# Altium schematic sheet UAARG Autopilot.SchDoc
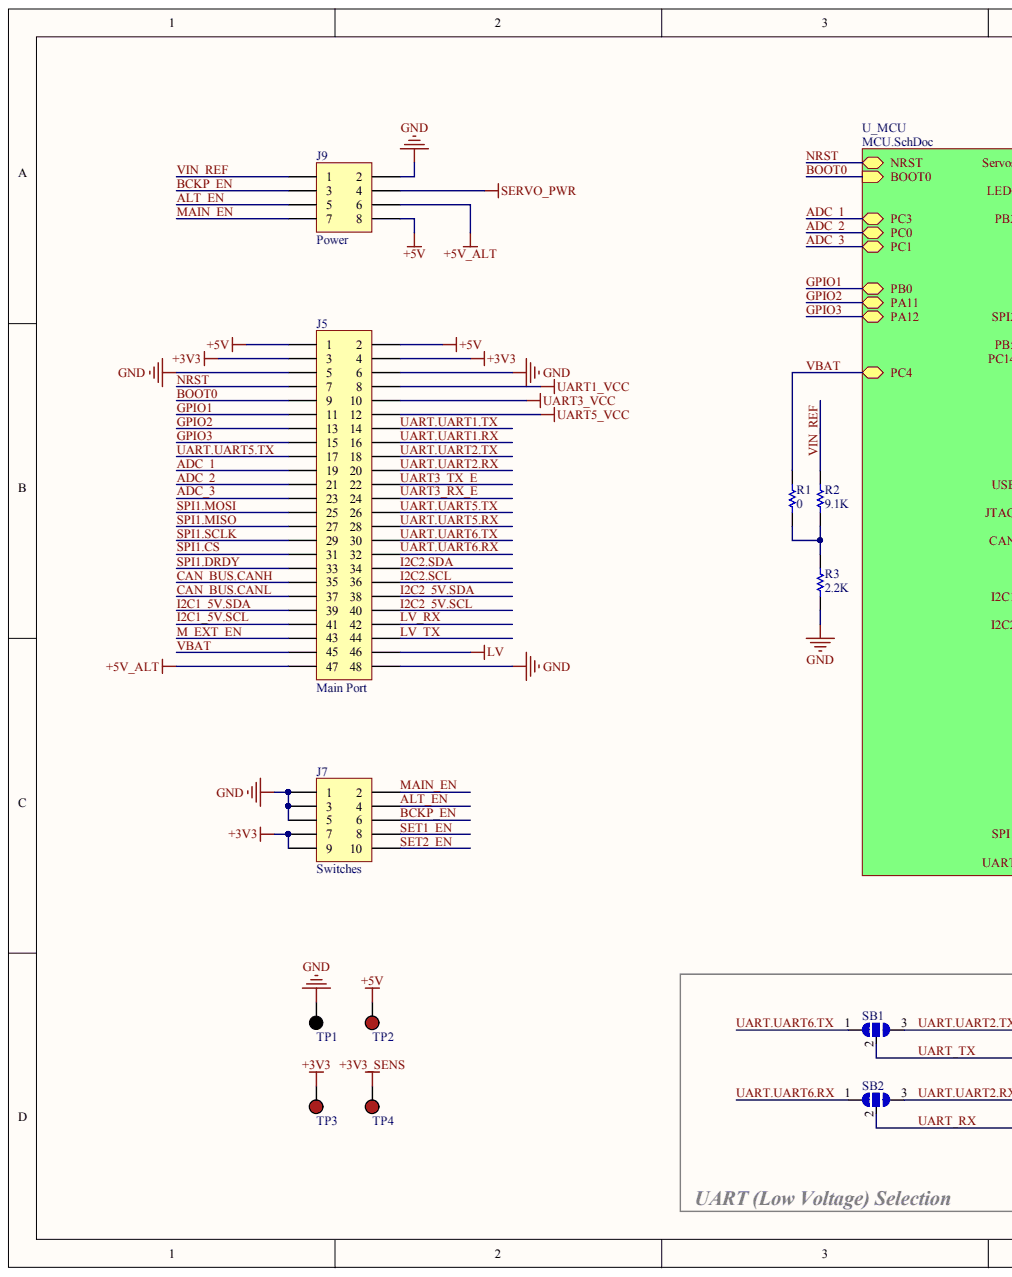
[diagram p0: UAARG Autopilot.SchDoc, left]
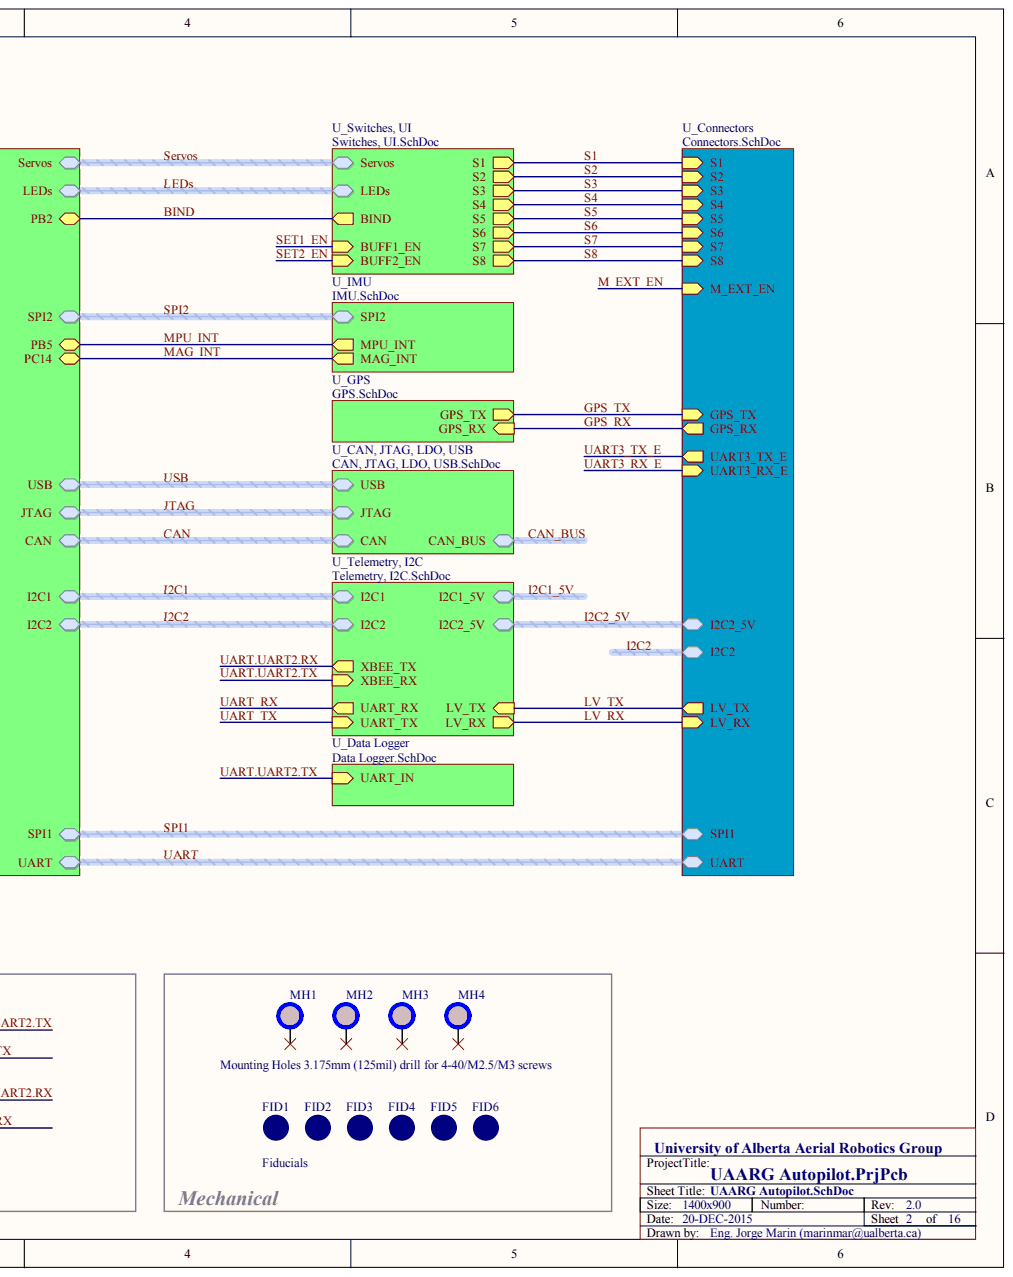
[diagram p1: UAARG Autopilot.SchDoc, right]

## Parts
FID1  Ficudial  [FIDUCIAL_1]  (value not drawn)  (no BOM)
FID2  Ficudial  [FIDUCIAL_1]  (value not drawn)  (no BOM)
FID3  Ficudial  [FIDUCIAL_1]  (value not drawn)  (no BOM)
FID4  Ficudial  [FIDUCIAL_1]  (value not drawn)  (no BOM)
FID5  Ficudial  [FIDUCIAL_1]  (value not drawn)  (no BOM)
FID6  Ficudial  [FIDUCIAL_1]  (value not drawn)  (no BOM)
J5  Main Port  [HDR_2X24]  (no BOM)
J7  Switches  [HDR_2X05]  (no BOM)
J9  Power  [2X04]  (no BOM)
MH1  Mounting Hole  [MOUNTINGHOLE_6]  (no BOM)
MH2  Mounting Hole  [MOUNTINGHOLE_6]  (no BOM)
MH3  Mounting Hole  [MOUNTINGHOLE_6]  (no BOM)
MH4  Mounting Hole  [MOUNTINGHOLE_6]  (no BOM)
R1  value 0  [RES_0402[1005M]]  (hidden comment: RES)
R2  value 9.1K  [RES_0603[1608M]]  (hidden comment: RES)
R3  value 2.2K  [RES_0603[1608M]]  (hidden comment: RES)
SB1  SMD Jumper 1  [SMD JUMPER 1]  (value not drawn)  (no BOM)
SB2  SMD Jumper 1  [SMD JUMPER 1]  (value not drawn)  (no BOM)
TP1  Test Point GND  [TP_TH_1_SILK]  (value not drawn)  (no BOM)
TP2  Test Point VCC  [TP_TH_1_SILK]  (value not drawn)  (no BOM)
TP3  Test Point VCC  [TP_TH_1_SILK]  (value not drawn)  (no BOM)
TP4  Test Point VCC  [TP_TH_1_SILK]  (value not drawn)  (no BOM)

## Nets
+3V3: J5.3 J5.4 J7.7 J7.9 TP3.1
+3V3_SENS: TP4.1
+5V: J5.1 J5.2 J9.8 TP2.1
+5V_ALT: J5.47 J9.6
GND: J5.5 J5.6 J5.48 J7.1 J7.3 J7.5 J9.2 R3.1 TP1.1
LV: J5.46
SERVO_PWR: J9.4
UART1_VCC: J5.8
UART3_VCC: J5.10
UART5_VCC: J5.12
ADC_1: J5.19
ADC_2: J5.21
ADC_3: J5.23
ALT_EN: J7.4 J9.5
BCKP_EN: J7.6 J9.3
BOOT0: J5.9
CAN_BUS.CANH: J5.35
CAN_BUS.CANL: J5.37
GPIO1: J5.11
GPIO2: J5.13
GPIO3: J5.15
I2C1_5V.SCL: J5.41
I2C1_5V.SDA: J5.39
I2C2.SCL: J5.36
I2C2.SDA: J5.34
I2C2_5V.SCL: J5.40
I2C2_5V.SDA: J5.38
LV_RX: J5.42
LV_TX: J5.44
M_EXT_EN: J5.43
MAIN_EN: J7.2 J9.7
NRST: J5.7
SET1_EN: J7.8
SET2_EN: J7.10
SPI1.CS: J5.31
SPI1.DRDY: J5.33
SPI1.MISO: J5.27
SPI1.MOSI: J5.25
SPI1.SCLK: J5.29
UART3_RX_E: J5.24
UART3_TX_E: J5.22
UART.UART1.RX: J5.16
UART.UART1.TX: J5.14
UART.UART2.RX: J5.20 SB2.3
UART.UART2.TX: J5.18 SB1.3
UART.UART5.RX: J5.28
UART.UART5.TX: J5.17 J5.26
UART.UART6.RX: J5.32 SB2.1
UART.UART6.TX: J5.30 SB1.1
UART_RX: SB2.2
UART_TX: SB1.2
VBAT: J5.45 R1.2
VIN_REF: J9.1 R2.2
NetMH1_1: MH1.1
NetMH2_1: MH2.1
NetMH3_1: MH3.1
NetMH4_1: MH4.1
NetR1_1: R1.1 R2.1 R3.2
BIND:
CAN.RX:
CAN.TX:
GPS_RX:
GPS_TX:
I2C1.SCL:
I2C1.SDA:
JTAG.RST:
JTAG.TCK:
JTAG.TDI:
JTAG.TDO:
JTAG.TMS:
LEDS.LED1:
LEDS.LED2:
LEDS.LED3:
LEDS.LED4:
LEDS.LED5:
MAG_INT:
MPU_INT:
S1:
S2:
S3:
S4:
S5:
S6:
S7:
S8:
SERVOS.SERVO1:
SERVOS.SERVO2:
SERVOS.SERVO3:
SERVOS.SERVO4:
SERVOS.SERVO5:
SERVOS.SERVO6:
SERVOS.SERVO7:
SERVOS.SERVO8:
SPI2.CS_BARO:
SPI2.CS_MPU:
SPI2.MISO:
SPI2.MOSI:
SPI2.SCLK:
UART.UART3.RX:
UART.UART3.TX:
USB.D_N:
USB.D_P:
USB.VBUS:

## Library data of the parts
FID1-FID6 = Ficudial: Fiducial; Library Name: UAARG Autopilot.SchLib
J5 = Header 24X2: Header, 24-Pin, Dual row; Library Name: Miscellaneous Connectors.IntLib; Signal Integrity: Connector
J7 = Header 5X2: Header, 5-Pin, Dual row; Library Name: Miscellaneous Connectors.IntLib; Signal Integrity: Connector
J9 = Header 4X2: Header, 4-Pin, Dual row; Library Name: Miscellaneous Connectors.IntLib; Signal Integrity: Connector
R1 = RES: Resistor; Manufacturer: Yageo; Manufacturer Part Number: RC0402JR-070RL; Component Kind: Standard; ComponentLink1Description: Datasheet; ComponentLink1URL: http://www.yageo.com/NewPortal/yageodocoutput?fileName=/pdf/R-Chip/PYu-RC_Group_51_RoHS_L_3.pdf; Signal Integrity: Res Semi; Simulation: RES; Supplier 1: Digi-Key; Supplier Part Number 1: 311-0.0JRCT-ND; Library Name: UAARG Autopilot.SchLib
R2 = RES: Resistor; Manufacturer: Yageo; Manufacturer Part Number: RC0603FR-079K1L; Component Kind: Standard; ComponentLink1Description: Datasheet; ComponentLink1URL: http://www.yageo.com/NewPortal/yageodocoutput?fileName=/pdf/R-Chip/PYu-RC_Group_51_RoHS_L_3.pdf; Signal Integrity: Res Semi; Simulation: RES; Supplier 1: Digi-Key; Supplier Part Number 1: 311-9.10KHRCT-ND; Library Name: UAARG Autopilot.SchLib
R3 = RES: Resistor; Manufacturer: Yageo; Manufacturer Part Number: RC0603FR-072K2L; Component Kind: Standard; ComponentLink1Description: Datasheet; ComponentLink1URL: http://www.yageo.com/NewPortal/yageodocoutput?fileName=/pdf/R-Chip/PYu-RC_Group_51_RoHS_L_3.pdf; Signal Integrity: Res Semi; Simulation: RES; Supplier 1: Digi-Key; Supplier Part Number 1: 311-2.20KHRCT-ND; Library Name: UAARG Autopilot.SchLib
SB1 SB2 = SMD Jumper 1: SMD Jumper 3 positions. Open; Library Name: UAARG Autopilot.SchLib
TP1 = Test Point GND: Test Point Ground; Library Name: UAARG Autopilot.SchLib
TP2-TP4 = Test Point VCC: Test Point Power; Library Name: UAARG Autopilot.SchLib
MH1-MH4 = Mounting Hole: Description: Mounting Hole; Library Name: UAARG Autopilot.SchLib
